# Altium schematic sheet Rx_6N137.SchDoc
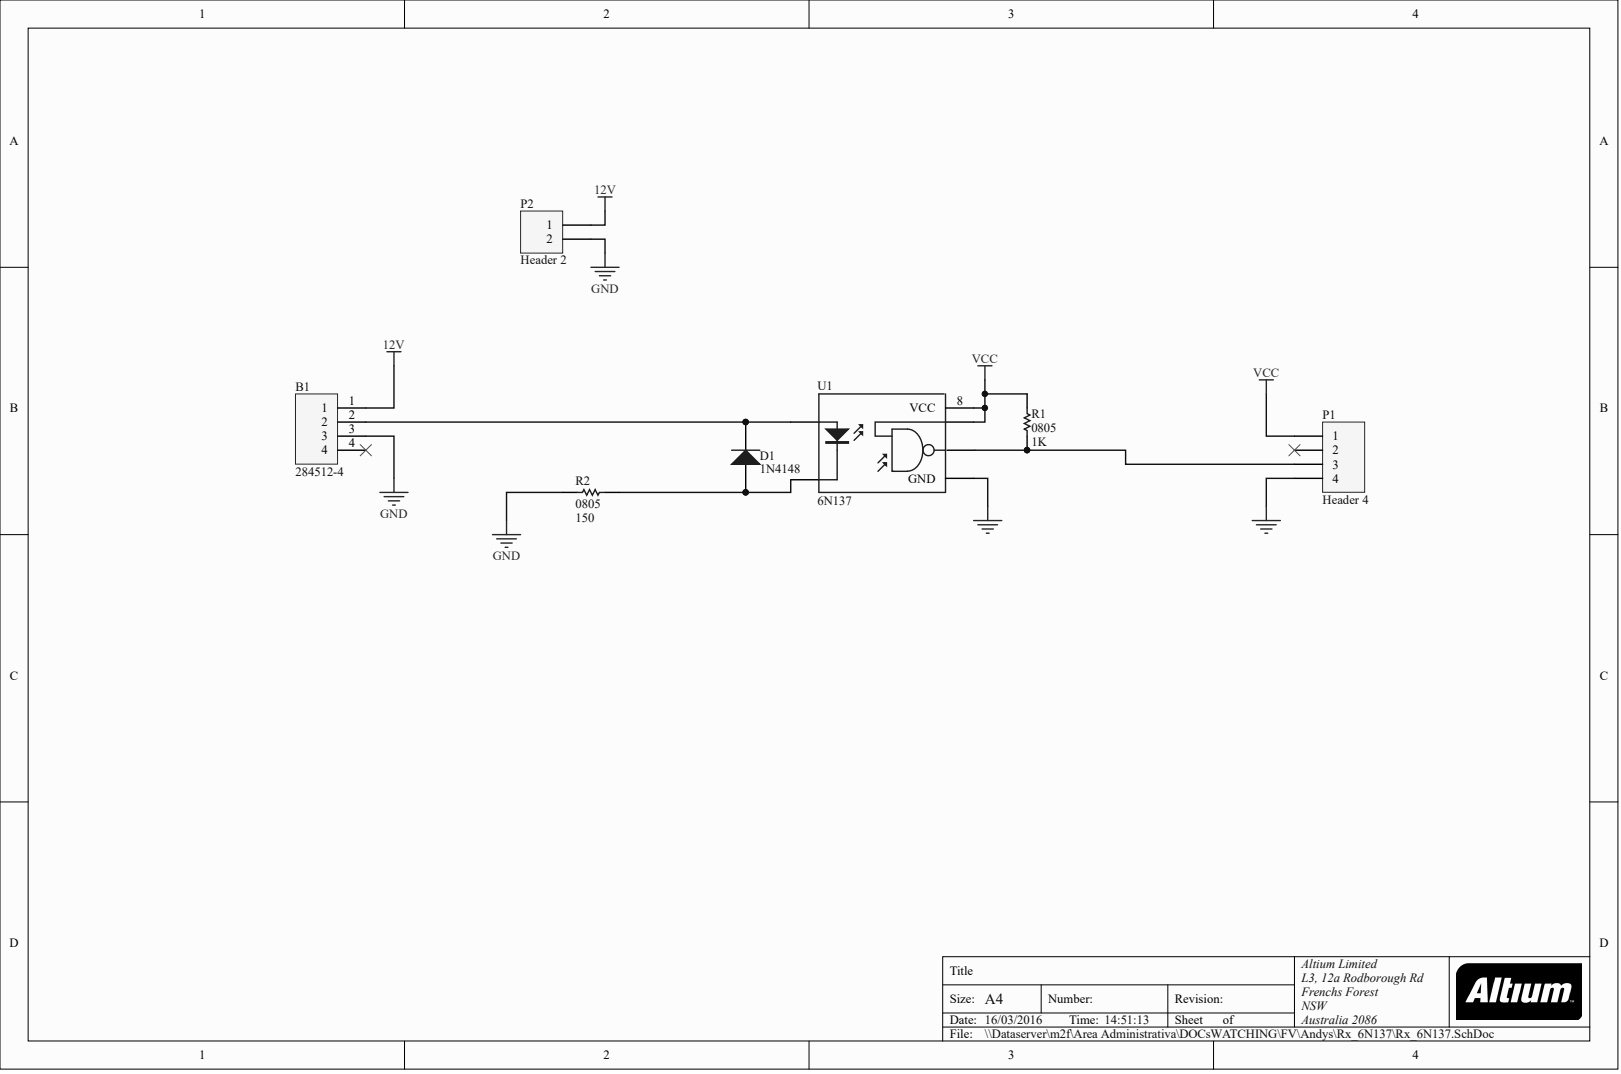
[diagram p0: Rx_6N137.SchDoc]

## Parts
B1  284512-4
D1  1N4148
P1  Header 4
P2  Header 2
R1  0805  value 1K
R2  0805  value 150
U1  6N137

## Nets
12V: B1.1 P2.1
GND: B1.3 P1.4 P2.2 R2.1 U1.5(GND)
VCC: P1.1 R1.2 U1.7 U1.8(VCC)
NetB1_2: B1.2 D1.2 U1.2
NetD1_1: D1.1 R2.2 U1.3
NetP1_3: P1.3 R1.1 U1.6

Not connected: B1 4; P1 2

## Library data of the parts
B1 = (no symbol name): Part: A98398-ND; Proveedor: Digikey; LatestRevisionDate: 17-Jul-2002; LatestRevisionNote: Re-released for DXP Platform.; : 0.64/125; Price: 0.15; Publisher: Altium Limited
D1 = (no symbol name): Manufacturer: Generic Components; Class I: Discrete; Class II: Diode - Switching; Published: 8-Jun-2000; Revision: July-2002: Re-released for DXP Platform.; Publisher: Altium Limited
P1 P2 R1 R2 U1 = (no symbol name)
  P1 P2: LatestRevisionDate: 17-Jul-2002; LatestRevisionNote: Re-released for DXP Platform.
  R1 R2: Published: 8-Jun-2000; LatestRevisionDate: 17-Jul-2002; LatestRevisionNote: Re-released for DXP Platform.; PackageReference: AXIAL-0.5
  U1: Published: 24-Mar-1999; Revision: July-2002: Re-released for DXP Platform.; Publisher: Fernando Vásquez
  P1 P2 R1 R2: Publisher: Altium Limited
